# Altium schematic sheet lis3dsh_i2c.SchDoc
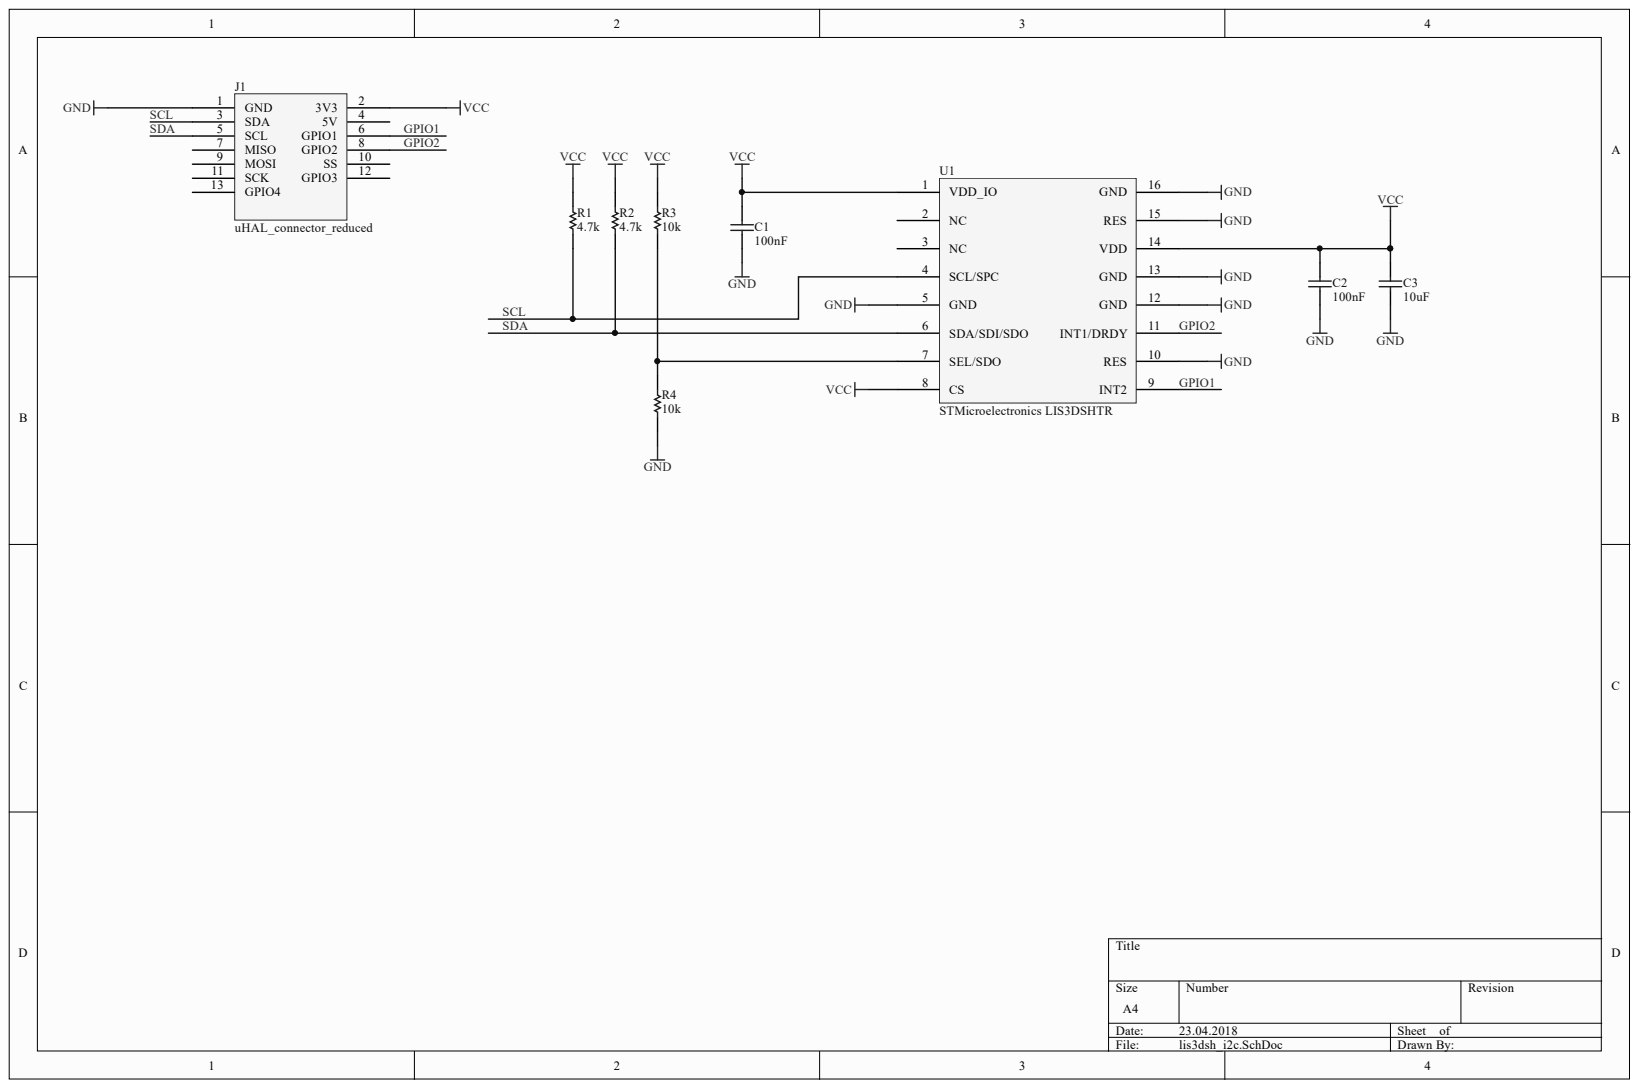
[diagram p0: lis3dsh_i2c.SchDoc]

## Parts
C1  Capacitance: 100nF  [CAPC1608X90X35ML10T15]  (hidden comment: Murata GCM188R71H104KA57D)
C2  Capacitance: 100nF  [CAPC1608X90X35ML10T15]  (hidden comment: Murata GCM188R71H104KA57D)
C3  Capacitance: 10uF  [CAPC1608X90X35ML10T15]  (hidden comment: Murata GCM188R71H104KA57D)
J1  uHAL_connector_reduced  [PCB-45ncoppm4oopozvqifsq-1]
R1  4.7k  [RESC1608X05N]
R2  4.7k  [RESC1608X05N]
R3  10k  [RESC1608X05N]
R4  10k  [RESC1608X05N]
U1  STMicroelectronics LIS3DSHTR  [STM-LGA-16_M]

## Nets
GND: C1.2 C2.2 C3.2 J1.1(GND) R4.1 U1.5(GND) U1.10(RES) U1.12(GND) U1.13(GND) U1.15(RES) U1.16(GND)
VCC: C1.1 C2.1 C3.1 J1.2(3V3) R1.2 R2.2 R3.2 U1.1(VDD_IO) U1.8(CS) U1.14(VDD)
GPIO1: J1.6(GPIO1) U1.9(INT2)
GPIO2: J1.8(GPIO2) U1.11(INT1/DRDY)
SCL: J1.3(SDA) R1.1 U1.4(SCL/SPC)
SDA: J1.5(SCL) R2.1 U1.6(SDA/SDI/SDO)
NetR3_1: R3.1 R4.2 U1.7(SEL/SDO)

Not connected: J1 4(5V) 7(MISO) 9(MOSI) 10(SS) 11(SCK) 12(GPIO3) 13(GPIO4); U1 2(NC) 3(NC)

## Library data of the parts
C1-C3 = CMP-119667-3: CAP CER 0.1UF 50V 10% X7R 0603; Lead-Free Status: Lead Free; Voltage Rating (DC): 50.0 V; Capacitance Tolerance: ±10%; Component Kind: Standard; Dielectric Characteristic: X7R; Library Name: CommunityVault; OctopartID: 3480fdf266eeeb47; Octopart Url: https://octopart.com/gcm188r71h104ka57d-murata-7894792; Packaging: Cut Tape (CT); REACH SVHC Compliance: No SVHC; RoHS: Compliant
J1 = CMP-2q23h2cwhjlfm8s6r7i8-1: connector for uHAL with reduced pin number; Component Kind: Standard; CustomComponentID: 2q23h2cwhjlfm8s6r7i8; Library Name: CommunityVault
R1-R4 = CMP-31acf99032f6ee6b-1: RCA Series 0603 0.1 W 0 O Jumper Surface Mount Thick Film Chip Resistor; Manufacturer Part Number: RCA06030000ZSEA; Case/Package (SI): 1608; Lead-Free Status: Lead Free; Power Rating: 100 mW; Case/Package: 0603, 1608; Component Kind: Standard; Library Name: CommunityVault; OctopartID: 31acf99032f6ee6b; Octopart URL: https://octopart.com/rca06030000zsea-vishay-20325948; Packaging: Cut Tape (CT); RoHS: Compliant
U1 = CMP-40c388997c2c9f04-1: MEMS digital output motion sensor: ultra low-power high performance 3-axes nano accelerometer; Component Kind: Standard; Library Name: CommunityVault; Lifecycle Status: Active; OctopartID: 40c388997c2c9f04; Packaging: Reel; RoHS: Compliant
